# Altium schematic sheet Sheet3.SchDoc(Sheet3)
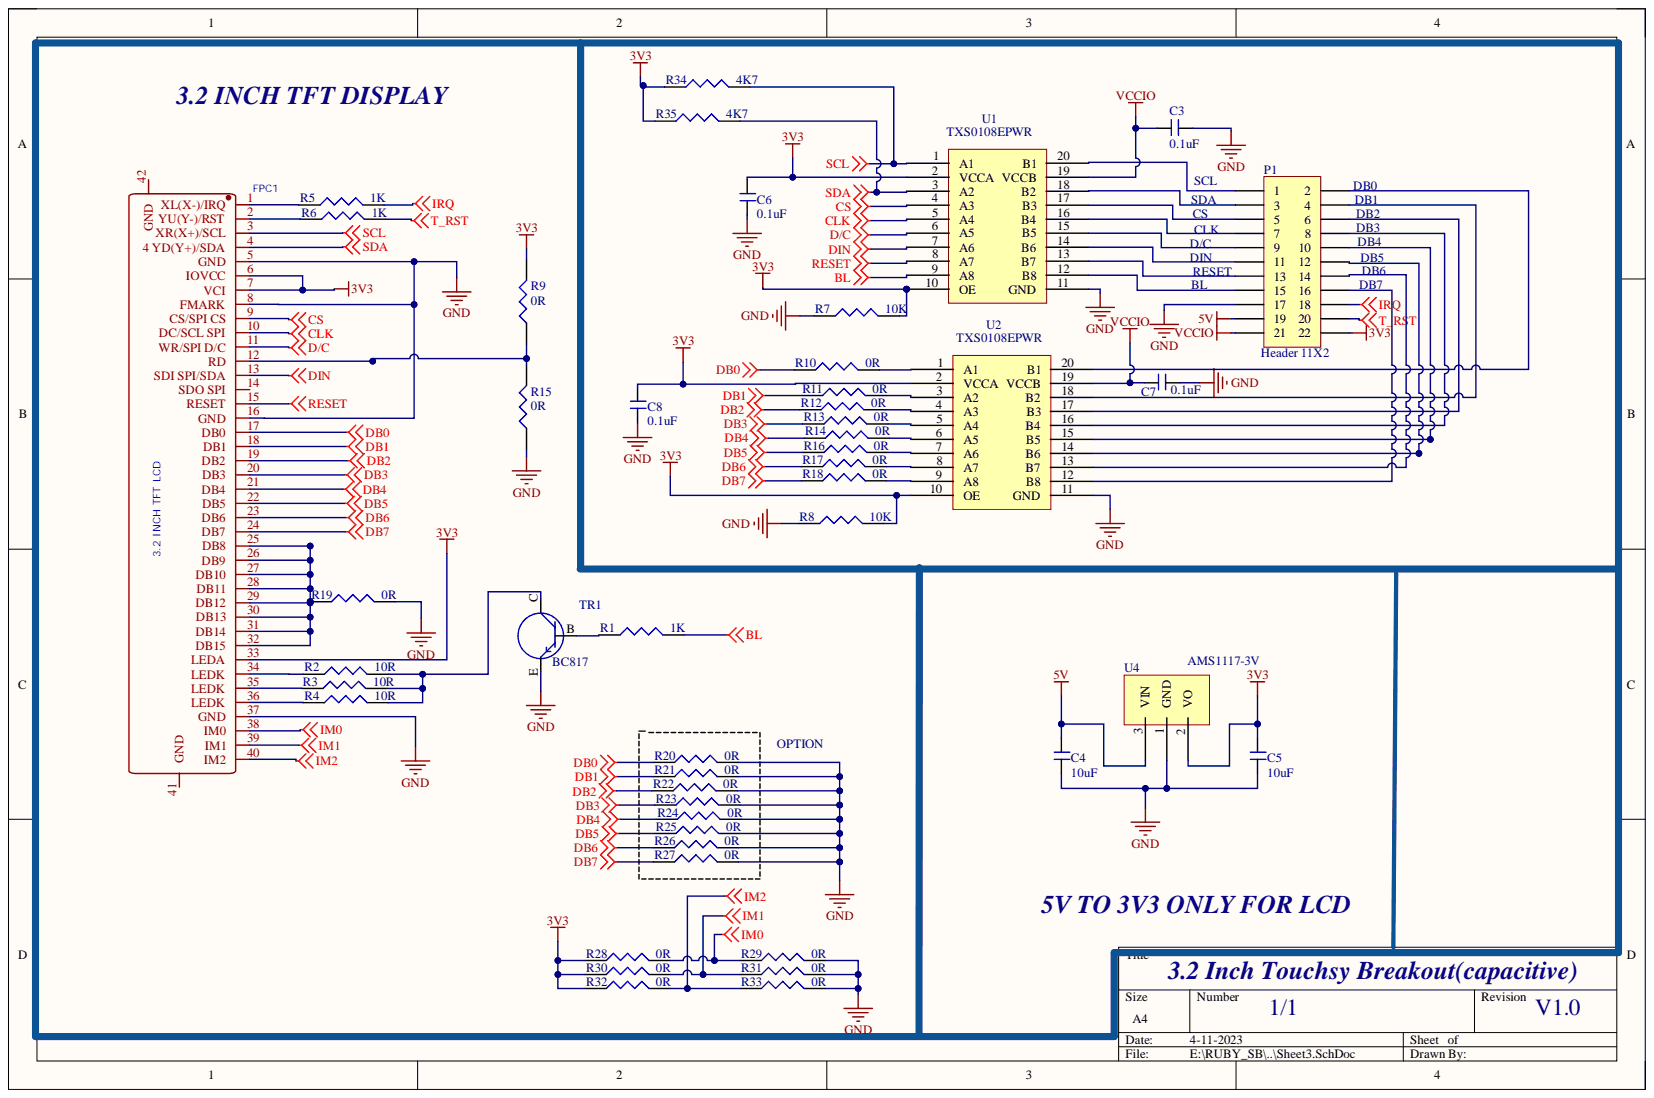
[diagram p0: Sheet3.SchDoc]

## Parts
C3  0.1uF  [C_0603]
C4  10uF  [C_0603]
C5  10uF  [C_0603]
C6  0.1uF  [C_0603]
C7  0.1uF  [C_0603]
C8  0.1uF  [C_0603]
FPC1  3.2 INCH TFT LCD  [3.2 TFT]
P1  Header 11X2  [HDR2X11]
R1  1K  [R_0603]
R2  10R  [R_0603]
R3  10R  [R_0603]
R4  10R  [R_0603]
R5  1K  [R_0603]
R6  1K  [R_0603]
R7  10K  [R_0603]
R8  10K  [R_0603]
R9  0R  [R_0603]
R10  0R  [R_0603]
R11  0R  [R_0603]
R12  0R  [R_0603]
R13  0R  [R_0603]
R14  0R  [R_0603]
R15  0R  [R_0603]
R16  0R  [R_0603]
R17  0R  [R_0603]
R18  0R  [R_0603]
R19  0R  [R_0603]
R20  0R  [R_0603]
R21  0R  [R_0603]
R22  0R  [R_0603]
R23  0R  [R_0603]
R24  0R  [R_0603]
R25  0R  [R_0603]
R26  0R  [R_0603]
R27  0R  [R_0603]
R28  0R  [R_0603]
R29  0R  [R_0603]
R30  0R  [R_0603]
R31  0R  [R_0603]
R32  0R  [R_0603]
R33  0R  [R_0603]
R34  4K7  [R_0603]
R35  4K7  [R_0603]
TR1  BC817  [SOT23]
U1  TXS0108EPWR  [TSSOP 20P]
U2  TXS0108EPWR  [TSSOP 20P]
U4  AMS1117-3V  [AMS1117_3V3]

## Nets
3V3: C5.2 C6.2 C8.2 FPC1.6(IOVCC) FPC1.7(VCI) FPC1.33(LEDA) P1.22 R7.2 R8.2 R9.2 R28.1 R30.1 R32.1 R34.1 R35.1 U1.2(VCCA) U1.10(OE) U2.2(VCCA) U2.10(OE) U4.2
5V: C4.2 P1.19 U4.3
BL: R1.2 U1.9(A8)
CLK: FPC1.10(DC/SCL_SPI) U1.5(A4)
CS: FPC1.9(CS/SPI_CS) U1.4(A3)
D/C: FPC1.11(WR/SPI_D/C) U1.6(A5)
DB0: FPC1.17(DB0) R10.1 R20.1
DB1: FPC1.18(DB1) R11.1 R21.1
DB2: FPC1.19(DB2) R12.1 R22.1
DB3: FPC1.20(DB3) R13.1 R23.1
DB4: FPC1.21(DB4) R14.1 R24.1
DB5: FPC1.22(DB5) R16.1 R25.1
DB6: FPC1.23(DB6) R17.1 R26.1
DB7: FPC1.24(DB7) R18.1 R27.1
DIN: FPC1.13(SDI_SPI/SDA) U1.7(A6)
GND: C3.1 C4.1 C5.1 C6.1 C7.1 C8.1 FPC1.5(GND) FPC1.8(FMARK) FPC1.16(GND) FPC1.37(GND) P1.17 R7.1 R8.1 R15.1 R19.2 R20.2 R21.2 R22.2 R23.2 R24.2 R25.2 R26.2 R27.2 R29.2 R31.2 R33.2 TR1.E U1.11(GND) U2.11(GND) U4.1
IM0: FPC1.38(IM0) R28.2 R29.1
IM1: FPC1.39(IM1) R30.2 R31.1
IM2: FPC1.40(IM2) R32.2 R33.1
IRQ: P1.18 R5.2
RESET: FPC1.15(RESET) U1.8(A7)
SCL: FPC1.3(XR(X+)/SCL) R34.2 U1.1(A1)
SDA: FPC1.4(4_YD(Y+)/SDA) R35.2 U1.3(A2)
T_RST: P1.20 R6.2
VCCIO: C3.2 C7.2 P1.21 U1.19(VCCB) U2.19(VCCB)
NetFPC1_1: FPC1.1(XL(X-)/IRQ) R5.1
NetFPC1_2: FPC1.2(YU(Y-)/RST) R6.1
NetFPC1_12: FPC1.12(RD) R9.1 R15.2
NetFPC1_25: FPC1.25(DB8) FPC1.26(DB9) FPC1.27(DB10) FPC1.28(DB11) FPC1.29(DB12) FPC1.30(DB13) FPC1.31(DB14) FPC1.32(DB15) R19.1
NetFPC1_34: FPC1.34(LEDK) R2.1
NetFPC1_35: FPC1.35(LEDK) R3.1
NetFPC1_36: FPC1.36(LEDK) R4.1
NetP1_1: P1.1 U1.20(B1)
NetP1_2: P1.2 U2.20(B1)
NetP1_3: P1.3 U1.18(B2)
NetP1_4: P1.4 U2.18(B2)
NetP1_5: P1.5 U1.17(B3)
NetP1_6: P1.6 U2.17(B3)
NetP1_7: P1.7 U1.16(B4)
NetP1_8: P1.8 U2.16(B4)
NetP1_9: P1.9 U1.15(B5)
NetP1_10: P1.10 U2.15(B5)
NetP1_11: P1.11 U1.14(B6)
NetP1_12: P1.12 U2.14(B6)
NetP1_13: P1.13 U1.13(B7)
NetP1_14: P1.14 U2.13(B7)
NetP1_15: P1.15 U1.12(B8)
NetP1_16: P1.16 U2.12(B8)
NetR1_1: R1.1 TR1.B
NetR2_2: R2.2 R3.2 R4.2 TR1.C
NetR10_2: R10.2 U2.1(A1)
NetR11_2: R11.2 U2.3(A2)
NetR12_2: R12.2 U2.4(A3)
NetR13_2: R13.2 U2.5(A4)
NetR14_2: R14.2 U2.6(A5)
NetR16_2: R16.2 U2.7(A6)
NetR17_2: R17.2 U2.8(A7)
NetR18_2: R18.2 U2.9(A8)

Not connected: FPC1 14(SDO_SPI) 41(GND) 42(GND)

## Library data of the parts
C3-C8 = CAP_NONPOLAR: Component Kind: Standard; Library Name: dxp_pasl_lib.IntLib
FPC1 = FPC-40P: Component Kind: Standard; Library Name: MIX.SchLib
P1 = Header 11X2: Header, 11-Pin, Dual row; Component Kind: Standard; LatestRevisionDate: 17-Jul-2002; LatestRevisionNote: Re-released for DXP Platform.; Library Name: Miscellaneous Connectors.IntLib; Signal Integrity: Connector; Publisher: Altium Limited
R1-R35 = RES: Component Kind: Standard; Library Name: dxp_pasl_lib.IntLib
TR1 = TRANSISTER_NPN: Component Kind: Standard; Library Name: dxp_pasl_lib.IntLib
U1 U2 = TXS0108EPWR: Level Translators, Shifters RoHS TSSOP-20 # C53406; Component Kind: Standard; Library Name: MIX.SchLib
U4 = AMS1117: Component Kind: Standard; Library Name: dxp_pasl_lib.IntLib
